# Altium schematic sheet Top Level.SchDoc("Top Level")
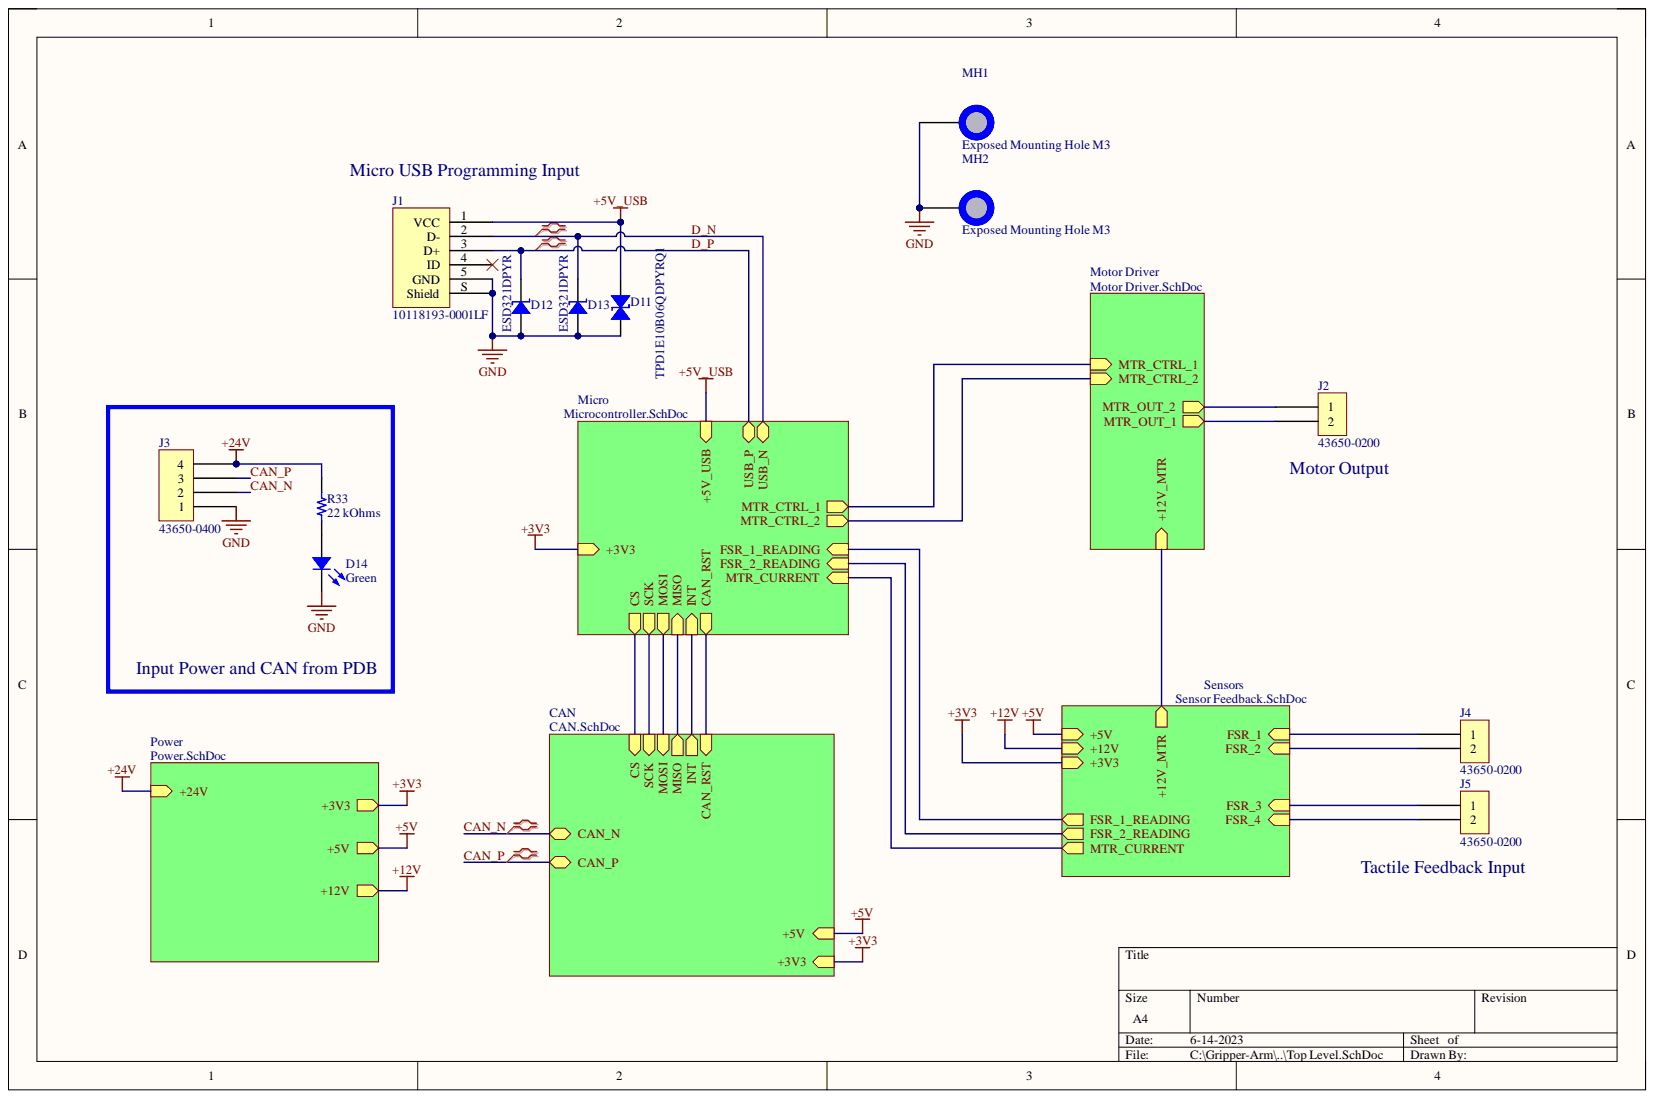
[diagram p0: Top Level.SchDoc]

## Parts
D11  TPD1E10B06QDPYRQ1  [0402]
D12  ESD321DPYR  [0402]
D13  ESD321DPYR  [0402]
D14  Green  [LED 0603_1608 GREEN]
J1  10118193-0001LF  [FCI USB 10118193-0001LF]
J2  43650-0200  [43650-0200-Footprint-1]
J3  43650-0400  [43650-0400-Footprint-1]
J4  43650-0200  [43650-0200-Footprint-1]
J5  43650-0200  [43650-0200-Footprint-1]
MH1  Exposed Mounting Hole M3  [Mounting Hole M3-Footprint-1]
MH2  Exposed Mounting Hole M3  [Mounting Hole M3-Footprint-1]
R33  22 kOhms  [RES 0603_1608]

## Nets
+5V_USB: D11.1 J1.1(VCC)
+24V: J3.4 R33.2
GND: D11.2 D12.1 D13.1 D14.2 J1.5(GND) J1.S(Shield) J3.1 MH1.0 MH2.0
CAN_N: J3.2
CAN_P: J3.3
D_N: D13.2 J1.2(D-)
D_P: D12.2 J1.3(D+)
NetD14_1: D14.1 R33.1
NetJ1_4: J1.4(ID)
NetJ2_1: J2.1
NetJ2_2: J2.2
NetJ4_1: J4.1
NetJ4_2: J4.2
NetJ5_1: J5.1
NetJ5_2: J5.2
+3V3:
+5V:
+12V:
+12V_MTR:
CAN_RST:
CS:
FSR_1_READING:
FSR_2_READING:
INT:
MISO:
MOSI:
MTR_CTRL_1:
MTR_CTRL_2:
MTR_CURRENT:
SCK:

## Library data of the parts
D11 = TPD1E10B06QDPYRQ1: TVS DIODE 5.5VWM 14VC 2X1SON; Manufacturer: Texas Instruments; Bidirectional Channels: 1; Capacitance @ Frequency: 12pF @ 1MHz; Current - Peak Pulse (10/1000µs): 6A (8/20µs); Database Table Name: Diodes - TVS; Power - Peak Pulse: 90W; Power Line Protection: No; Type: Zener; Voltage - Breakdown (Min): 6V; Voltage - Clamping (Max) @ Ipp: 14V (Typ); Voltage - Reverse Standoff (Typ): 5.5V (Max); Applications: Automotive; Component Kind: Standard; ComponentLink1Description: Datasheet; ComponentLink1URL: http://www.ti.com/general/docs/suppproductinfo.tsp?distId=10&gotoUrl=http%3A%2F%2Fwww.ti.com%2Flit%2Fgpn%2Ftpd1e10b06-q1; ComponentLink2Description: DigiKey Link; ComponentLink2URL: https://www.digikey.com/en/products/detail/texas-instruments/TPD1E10B06QDPYRQ1/6609845; Device Package: 0402 (1005 Metric); LastUpdated: 2022-09-19 2:05:54 PM; Library Name: Celestial Altium Library - altium_library.DbLib; Lifecycle Status: Active; Minimum Order: 1; Mounting Type: Surface Mount; Operating Temperature: -40°C ~ 125°C (TA); Package / Case: 0402 (1005 Metric); Packaging: TapeAndReel; Part Number: TPD1E10B06QDPYRQ1; Part Status: Active; PartId: 10475; Price: 0.09; Series: Automotive, AEC-Q101; Supplier 1: DigiKey; Supplier Device Package: 2-X1SON (1x.60); Supplier Part Number 1: 296-TPD1E10B06QDPYRQ1CT-ND
D12 D13 = ESD321DPYR: TVS DIODE 3.6VWM 6.8VC 2X1SON; Manufacturer: Texas Instruments; Capacitance @ Frequency: 0.9pF @ 1MHz; Current - Peak Pulse (10/1000µs): 6A (8/20µs); Database Table Name: Diodes - TVS; Power - Peak Pulse: 40W; Power Line Protection: No; Type: Zener; Unidirectional Channels: 1; Voltage - Breakdown (Min): 4.5V; Voltage - Clamping (Max) @ Ipp: 6.8V (Typ); Voltage - Reverse Standoff (Typ): 3.6V (Max); Applications: General Purpose; Component Kind: Standard; ComponentLink1Description: Datasheet; ComponentLink1URL: http://www.ti.com/general/docs/suppproductinfo.tsp?distId=10&gotoUrl=http%3A%2F%2Fwww.ti.com%2Flit%2Fgpn%2Fesd321; ComponentLink2Description: DigiKey Link; ComponentLink2URL: https://www.digikey.com/en/products/detail/texas-instruments/ESD321DPYR/9559035; Device Package: 0402 (1005 Metric); LastUpdated: 2022-09-19 2:05:48 PM; Library Name: Celestial Altium Library - altium_library.DbLib; Lifecycle Status: Active; Minimum Order: 1; Mounting Type: Surface Mount; Operating Temperature: -40°C ~ 125°C (TA); Package / Case: 0402 (1005 Metric); Packaging: TapeAndReel; Part Number: ESD321DPYR; Part Status: Active; PartId: 11534; Price: 0.10; Series: -; Supplier 1: DigiKey; Supplier Device Package: 2-X1SON (1x.60); Supplier Part Number 1: 296-51108-1-ND
D14 = XZVGT53W-6: LED GREEN CLEAR 2SMD; Manufacturer: SunLED; Configuration: Standard; Current - Test: 20mA; Database Table Name: LED - Indication; Lens Color: Colorless; Lens Size: 1.20mm x 0.80mm; Lens Style: Rectangle with Flat Top; Millicandela Rating: 49mcd; Viewing Angle: 120°; Voltage - Forward (Vf) (Typ): 2.1V; Wavelength - Dominant: 570nm; Wavelength - Peak: 574nm; Color: Green; Component Kind: Standard; ComponentLink1Description: Datasheet; ComponentLink1URL: https://www.sunledusa.com/products/spec/XZVGT53W-6.pdf; ComponentLink2Description: DigiKey Link; ComponentLink2URL: https://www.digikey.com/en/products/detail/sunled/XZVGT53W-6/4902128; Device Package: 0603 (1608 Metric); Height (Max): 0.28mm; LastUpdated: 2022-09-13 7:29:38 AM; Lens Transparency: Clear; Library Name: Celestial Altium Library - altium_library.DbLib; Lifecycle Status: Active; Minimum Order: 1; Mounting Type: Surface Mount; Package / Case: 0603 (1608 Metric); Packaging: TapeAndReel; Part Number: XZVGT53W-6; Part Status: Active; PartId: 581; Price: 0.05; Series: -; Size / Dimension: 1.60mm L x 0.80mm W; Supplier 1: DigiKey; Supplier Device Package: 2-SMD; Supplier Part Number 1: 1497-1243-1-ND; Value: Green
J1 = 10118193-0001LF: CONN RCPT USB2.0 MICRO B SMD R/A; Manufacturer: Amphenol ICC (FCI); Connector Type: USB - micro B; Current Rating (Amps): 1.8A per Contact; Database Table Name: Connectors - USB DVI HDMI; Gender: Receptacle; Mating Cycles: 10000; Number of Contacts: 5; Number of Ports: 1; Shielding: Shielded; Voltage - Rated: 100VAC; Component Kind: Standard; ComponentLink1Description: Datasheet; ComponentLink1URL: https://cdn.amphenol-icc.com/media/wysiwyg/files/documentation/datasheet/inputoutput/io_usb_micro.pdf; ComponentLink2Description: DigiKey Link; ComponentLink2URL: //digikey.com/product-detail/en/amphenol-icc-fci/10118193-0001LF/609-4616-2-ND/2785388; Features: Solder Retention; LastUpdated: 2019-12-09 8:51:28 PM; Library Name: Celestial Altium Library - altium_library.DbLib; Lifecycle Status: 0; Minimum Order: 1; Mounting Feature: Horizontal; Mounting Type: Surface Mount, Right Angle; Through Hole; Operating Temperature: -30°C ~ 80°C; Packaging: TapeAndReel; Part Number: 10118193-0001LF; Part Status: Active; Price: 0.21; Series: -; Specifications: USB 2.0; Supplier 1: DigiKey; Supplier Part Number 1: 609-4616-2-ND; Termination: Solder
J2 J4 J5 = CMP-00070-1: Connector Header 2 Position 3 mm Solder Right Angle Thru-Hole 2 Terminal 1 Port Micro-Fit 3.0™; Connector Type: Plug; Contact Resistance: 10mR; Current Rating: 5A; Gender: Male; Glow Wire Compliant: Compliant; Insulation Resistance: 1GR; Number of Circuits: 2; Pitch: 3mm; Voltage Rating: 600V; Voltage Rating (AC): 250V; Color: Black; Component Kind: Standard; Contact Material: Brass,Tin; Contact Plating: Tin; Depth: 9.9mm; Flammability Rating: UL94 V-0; Halogen Free: Low Halogen; Height: 5.57mm; Housing Color: Black; Housing Material: Thermoplastic; Lead Free: Lead Free; Length: 9.65mm; Max Operating Temperature: 105°C; Min Operating Temperature: -40°C; Mounting Technology: Through Hole; Number of Contacts: 2; Number of Positions: 2; Number of Rows: 1; Orientation: Right Angle; Package Quantity: 3927; Packaging: Tray; Radiation Hardening: No; Reach SVHC Compliant: Unknown; RoHS Compliant: Yes; Series: Micro-Fit 3.0; Termination: Solder; Voltage Rating (DC): 250V; Working Voltage: 250V; Library Name: Americas- Environmental Engineering Club- University of Victoria
J3 = CMP-00071-1: Connector Wire to Board Header 4 Position 3mm Solder Right Angle Thru-Hole Tray; Connector Type: Plug; Contact Resistance: 10mR; Current Rating: 5A; Gender: Male; Glow Wire Compliant: Compliant; Max Voltage Rating (AC): 600V; Number of Circuits: 4; Voltage Rating (DC): 250V; Color: Black; Component Kind: Standard; Contact Material: Brass,Copper Alloy; Contact Plating: Tin; Depth: 3.6mm; Flammability Rating: UL94 V-0; Halogen Free: Low Halogen; Height: 4.5mm; Housing Color: Black; Housing Material: Thermoplastic; Lead Free: Contains Lead; Length: 14.86mm; Max Operating Temperature: 105°C; Min Operating Temperature: -40°C; Mounting Technology: Through Hole,Cable; Number of Contacts: 4; Number of Positions: 4; Number of Rows: 1; Orientation: Right Angle; Package Quantity: 2380; Packaging: Tray; Pitch: 3mm; Radiation Hardening: No; Reach SVHC Compliant: Unknown; RoHS Compliant: Yes; Series: 43650; Termination: Solder; Voltage Rating: 600V; Library Name: Americas- Environmental Engineering Club- University of Victoria
MH1 MH2 = CMP-00028-00002-1: M3 Mounting Hole Exposed; Component Kind: Standard; Library Name: Americas- Environmental Engineering Club- University of Victoria
R33 = CRCW060322K0FKEAC: RES 22K OHM 1% 1/10W 0603; Manufacturer: Vishay Dale; Database Table Name: Resistors - Surface Mount - 0603; Power (Watts): 0.1W, 1/10W; Temperature Coefficient: ±100ppm/°C; Component Kind: Standard; ComponentLink1Description: Datasheet; ComponentLink1URL: http://www.vishay.com/docs/28773/crcwce3.pdf; ComponentLink2Description: DigiKey Link; ComponentLink2URL: https://www.digikey.com/en/products/detail/vishay-dale/CRCW060322K0FKEAC/7928458; Composition: Thick Film; Device Package: 0603 (1608 Metric); Height - Seated (Max): 0.022" (0.55mm); LastUpdated: 2023-05-18 7:41:55 PM; Library Name: Celestial Altium Library - altium_library.DbLib; Lifecycle Status: Active; Minimum Order: 1; Number of Terminations: 2; Operating Temperature: -55°C ~ 155°C; Package / Case: 0603 (1608 Metric); Packaging: CutTape; Part Number: CRCW060322K0FKEAC; Part Status: Active; PartId: 12615; Price: 0.01; Resistance: 22 kOhms; Series: CRCW-C; Supplier 1: DigiKey; Supplier Device Package: 0603; Supplier Part Number 1: 541-4036-1-ND; Tolerance: ±1%; Value: 22 kOhms
